# Altium schematic sheet 06_KEY.SchDoc(06_KEY)
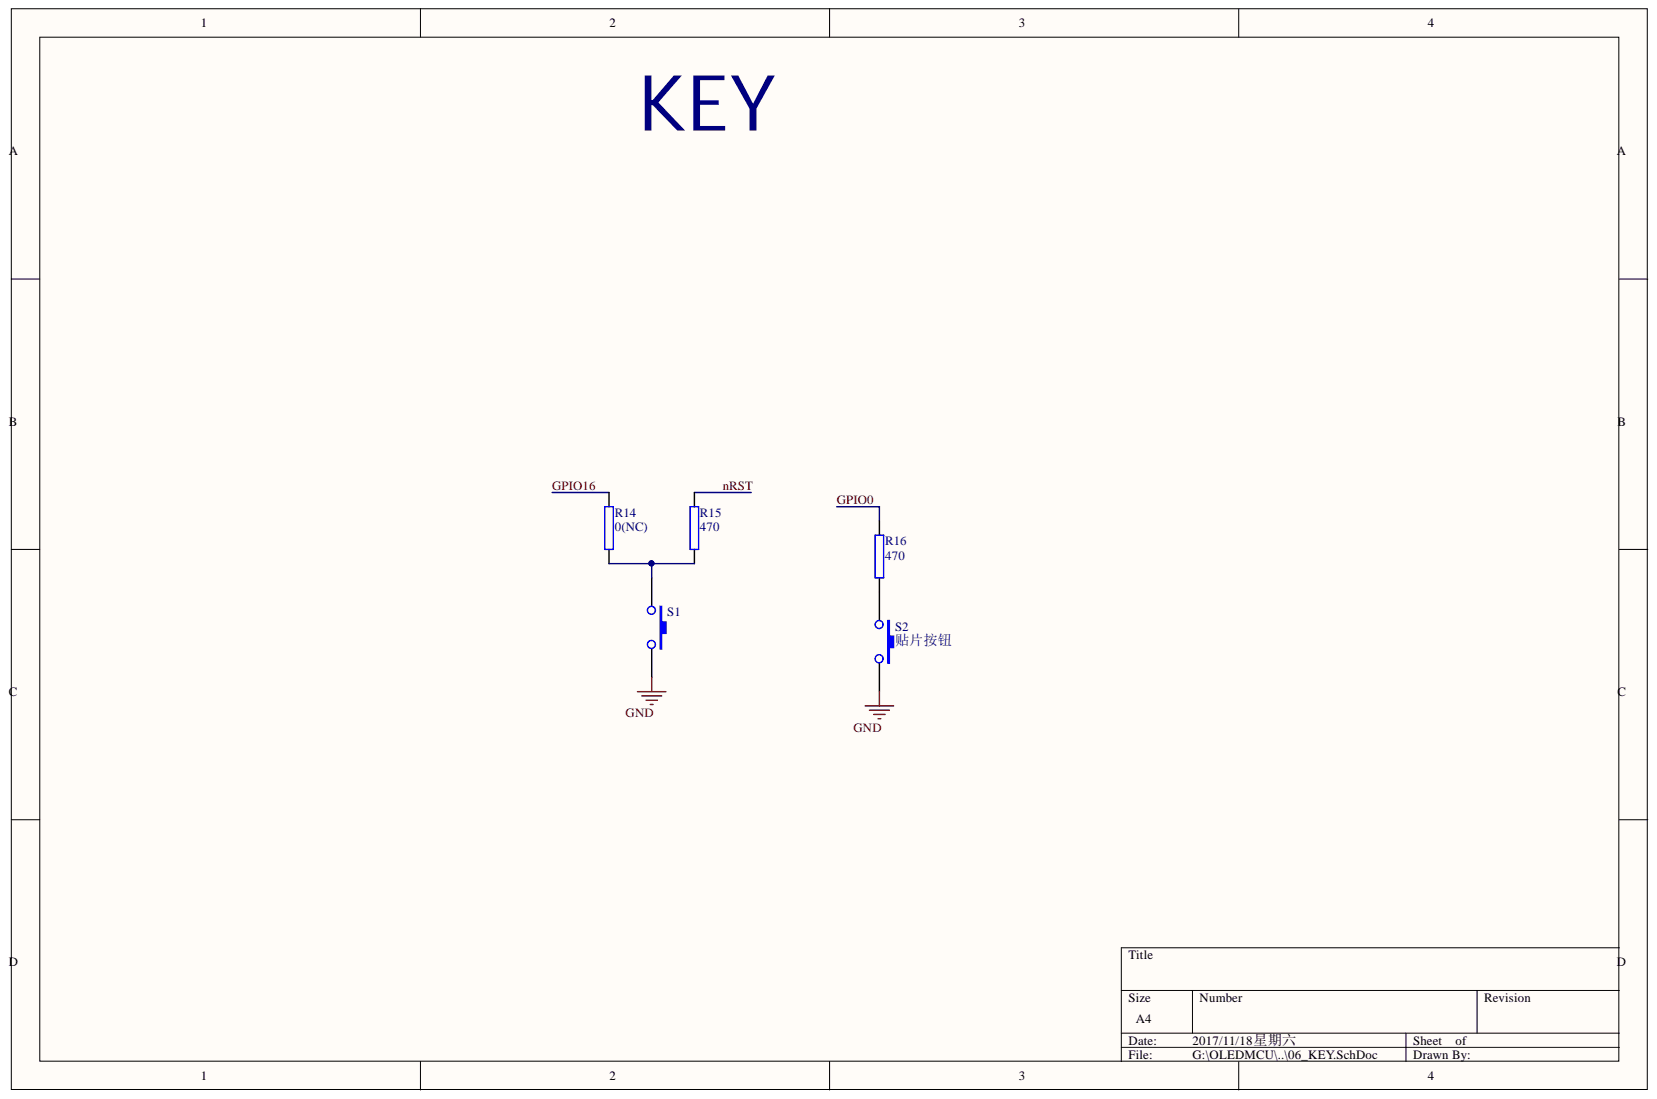
[diagram p0: 06_KEY.SchDoc]

## Parts
R14  0(NC)  [R0603]
R15  470  [R0603]
R16  470  [R0603]
S1  贴片按钮  [switch]  (value not drawn)
S2  贴片按钮  [switch]

## Nets
GND: S1.2 S2.2
GPIO0: R16.2
GPIO16: R14.2
NRST: R15.2
NetR14_1: R14.1 R15.1 S1.1
NetR16_1: R16.1 S2.1

## Library data of the parts
R14-R16 = R0603: 贴片电阻; Component Kind: Standard; Library Name: Schlib_OLEDMCU.SchLib
S1 S2 = Switch: 3*4*2mm开关; Component Kind: Standard; Library Name: Schlib_OLEDMCU.SchLib
